# Altium schematic sheet 04-SX1257.SchDoc(04-SX1257)
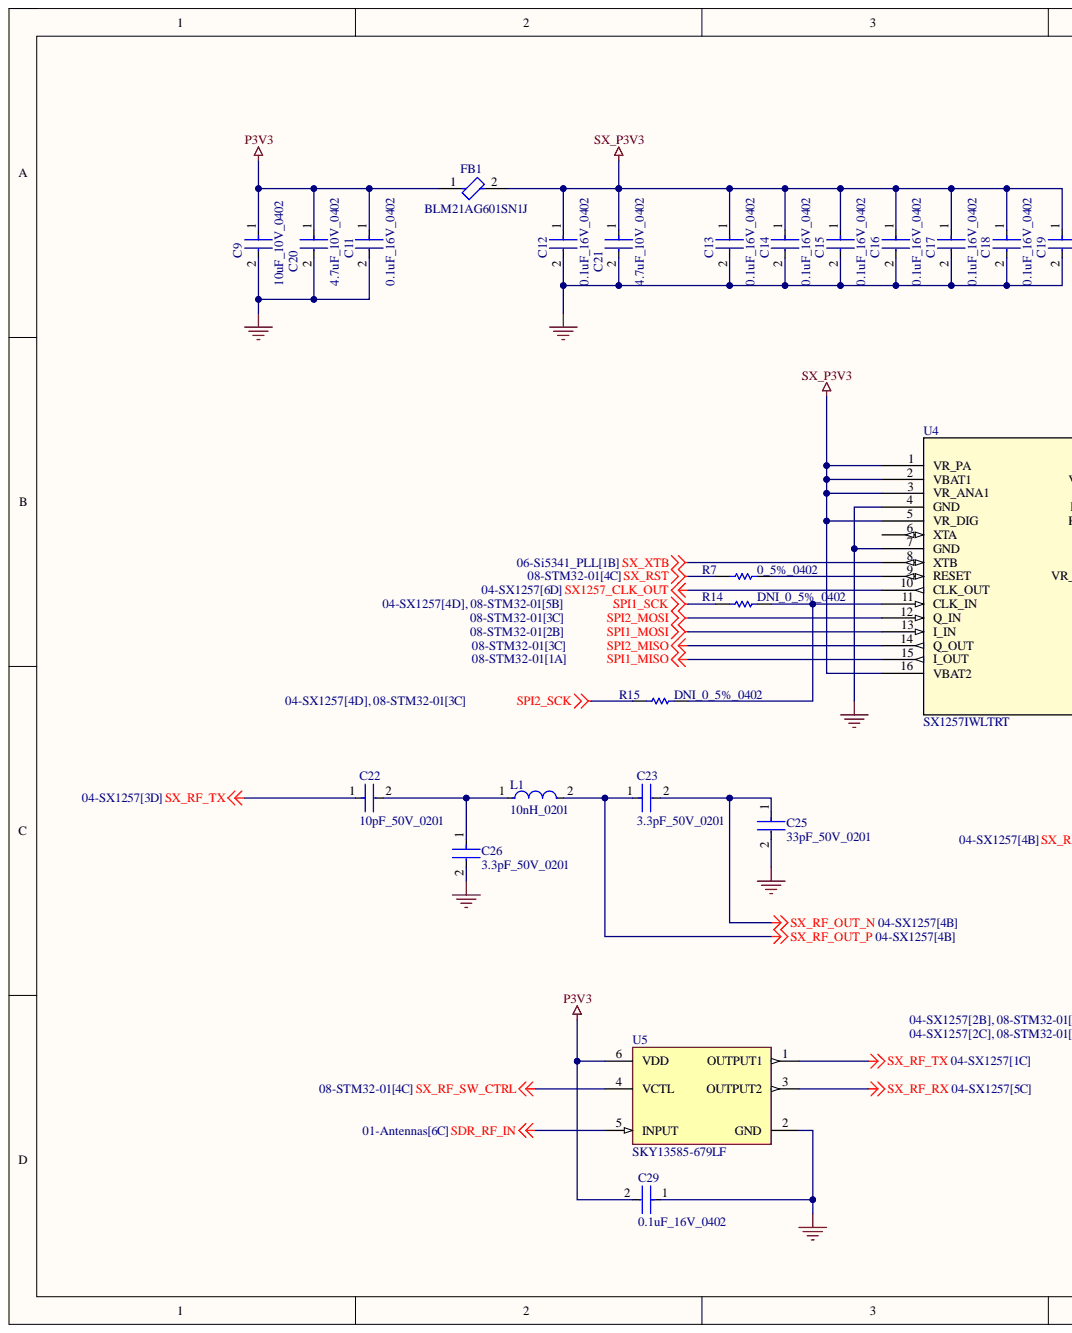
[diagram p0: 04-SX1257.SchDoc, left]
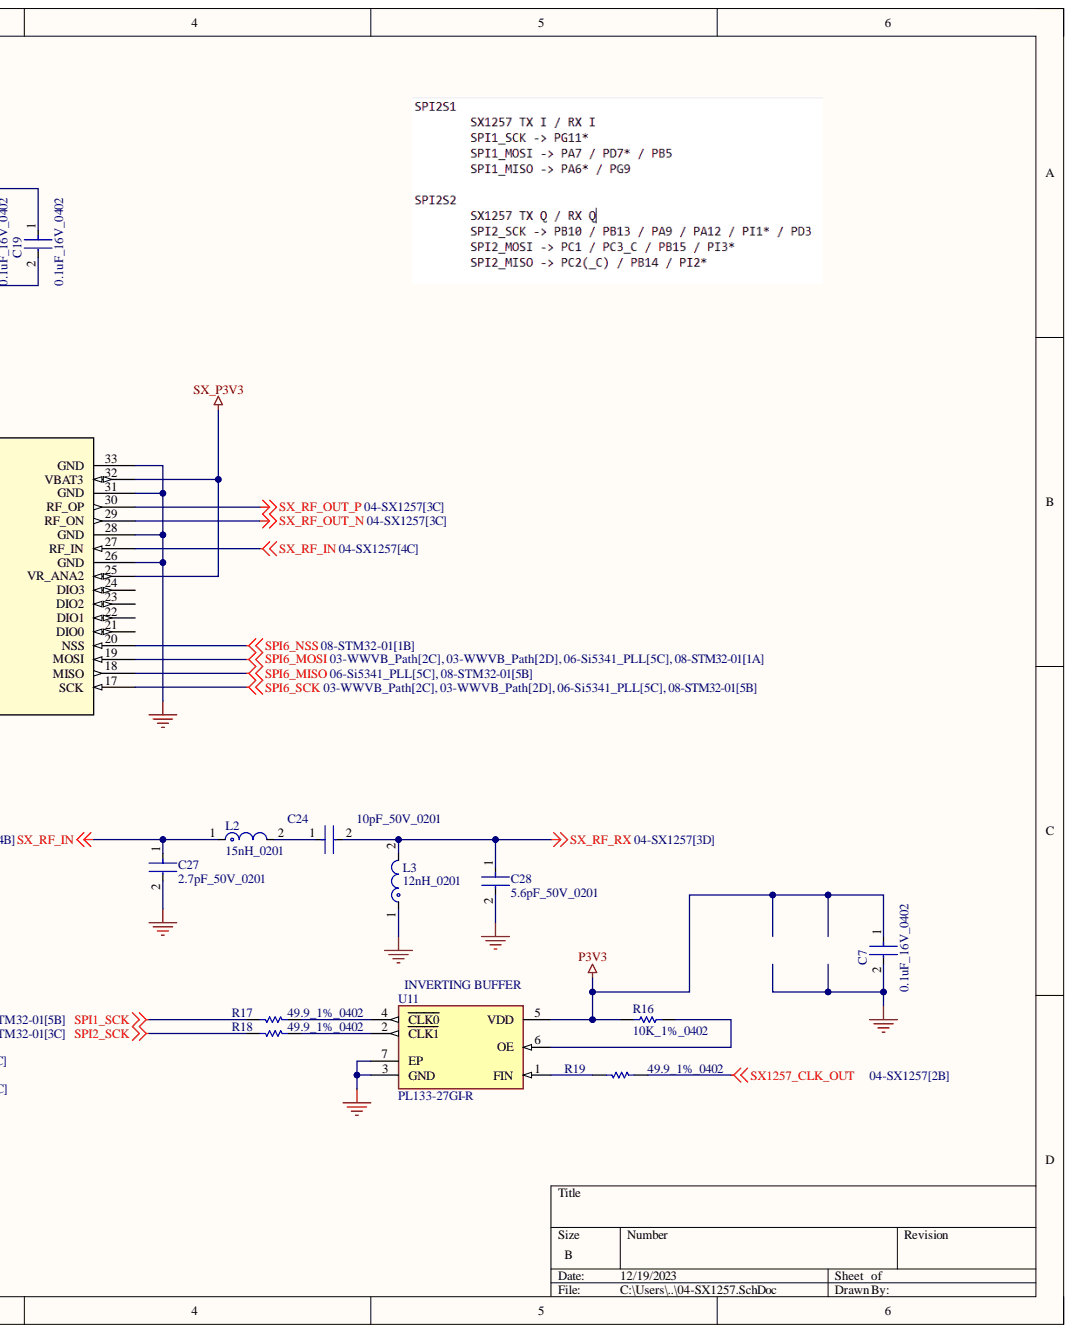
[diagram p1: 04-SX1257.SchDoc, right]

## Parts
C7  0.1uF_16V_0402  [FP-C0402-BB-MFG]
C9  10uF_10V_0402  [FP-CL05A106MP5NUNC-MFG]
C11  0.1uF_16V_0402  [FP-C0402-BB-MFG]
C12  0.1uF_16V_0402  [FP-C0402-BB-MFG]
C13  0.1uF_16V_0402  [FP-C0402-BB-MFG]
C14  0.1uF_16V_0402  [FP-C0402-BB-MFG]
C15  0.1uF_16V_0402  [FP-C0402-BB-MFG]
C16  0.1uF_16V_0402  [FP-C0402-BB-MFG]
C17  0.1uF_16V_0402  [FP-C0402-BB-MFG]
C18  0.1uF_16V_0402  [FP-C0402-BB-MFG]
C19  0.1uF_16V_0402  [FP-C0402-BB-MFG]
C20  4.7uF_10V_0402  [FP-C1005-050-0_15-MFG]
C21  4.7uF_10V_0402  [FP-C1005-050-0_15-MFG]
C22  10pF_50V_0201  [FP-CGA1A-0_1-0_03-MFG]
C23  3.3pF_50V_0201  [FP-GRM033-0_03-IPC_C]
C24  10pF_50V_0201  [FP-CGA1A-0_1-0_03-MFG]
C25  33pF_50V_0201  [FP-CGA1A-0_1-0_03-MFG]
C26  3.3pF_50V_0201  [FP-GRM033-0_03-IPC_C]
C27  2.7pF_50V_0201  [FP-GRM033-0_03-IPC_C]
C28  5.6pF_50V_0201  [FP-GRM033-0_03-MFG]
C29  0.1uF_16V_0402  [FP-C0402-BB-MFG]
FB1  BLM21AG601SN1J  [FP-BLM21-0_2-t0_85-e0_5-IPC_A]
L1  10nH_0201  [FP-LQP03HQ_02-MFG]
L2  15nH_0201  [FP-LQP03TG_02-IPC_C]
L3  12nH_0201  [FP-LQP03TG_02-MFG]
R7  0_5%_0402  [RESC1005X40X25NL05T10]
R14  DNI_0_5%_0402  [RESC1005X40X25NL05T10]  (no BOM)
R15  DNI_0_5%_0402  [RESC1005X40X25NL05T10]  (no BOM)
R16  10K_1%_0402  [RESC1005X40X25NL10T10]
R17  49.9_1%_0402  [RESC1005X40X25NL10T10]
R18  49.9_1%_0402  [RESC1005X40X25NL10T10]
R19  49.9_1%_0402  [RESC1005X40X25NL10T10]
U4  SX1257IWLTRT  [MLPQW-32_SEM]
U5  SKY13585-679LF  [FP-SKY13585-679LF-MFG]
U11  PL133-27GI-R  [FP-C04-1245-MFG]

## Nets
GND: C7.2 C9.2 C11.2 C12.2 C13.2 C14.2 C15.2 C16.2 C17.2 C18.2 C19.2 C20.2 C21.2 C25.2 C26.2 C27.2 C28.2 C29.1 L3.1 U4.4(GND) U4.7(GND) U4.26(GND) U4.28(GND) U4.31(GND) U4.33(GND) U5.2(GND) U11.3(GND) U11.7(EP)
P3V3: C7.1 C9.1 C11.1 C20.1 C29.2 FB1.1 R16.2 U5.6(VDD) U11.5(VDD)
SDR_RF_IN: U5.5(INPUT)
SPI1_MISO: U4.15(I_OUT)
SPI1_MOSI: U4.13(I_IN)
SPI1_SCK: R14.1 R17.1
SPI2_MISO: U4.14(Q_OUT)
SPI2_MOSI: U4.12(Q_IN)
SPI2_SCK: R15.1 R18.1
SPI6_MISO: U4.18(MISO)
SPI6_MOSI: U4.19(MOSI)
SPI6_NSS: U4.20(NSS)
SPI6_SCK: U4.17(SCK)
SX1257_CLK_OUT: R19.2 U4.10(CLK_OUT)
SX_P3V3: C12.1 C13.1 C14.1 C15.1 C16.1 C17.1 C18.1 C19.1 C21.1 FB1.2 U4.1(VR_PA) U4.2(VBAT1) U4.3(VR_ANA1) U4.5(VR_DIG) U4.16(VBAT2) U4.25(VR_ANA2) U4.32(VBAT3)
SX_RF_IN: C27.1 L2.1 U4.27(RF_IN)
SX_RF_OUT_N: C23.2 C25.1 U4.29(RF_ON)
SX_RF_OUT_P: C23.1 L1.2 U4.30(RF_OP)
SX_RF_RX: C24.2 C28.1 L3.2 U5.3(OUTPUT2)
SX_RF_SW_CTRL: U5.4(VCTL)
SX_RF_TX: C22.1 U5.1(OUTPUT1)
SX_RST: R7.1
SX_XTB: U4.8(XTB)
NetC22_2: C22.2 C26.1 L1.1
NetC24_1: C24.1 L2.2
NetR7_2: R7.2 U4.9(RESET)
NetR14_2: R14.2 R15.2 U4.11(CLK_IN)
NetR16_1: R16.1 U11.6(OE)
NetR17_2: R17.2 U11.4(CLK0)
NetR18_2: R18.2 U11.2(CLK1)
NetR19_1: R19.1 U11.1(FIN)

Not connected: U4 6(XTA) 21(DIO0) 22(DIO1) 23(DIO2) 24(DIO3)

## Library data of the parts
C7 C11-C19 C29 = CMP-1034-02068-3: CAP CER 0.1UF 16V 5% X7R 0402; Manufacturer: Kemet; Manufacturer Part Number: C0402C104J4RACTU; Capacitance: 0.10 μF; Material: Ceramic; Temperature Coefficient: 15%; Tolerance: 0.05; Value: 0.10 μF; Automotive: No; Category: Cap; Component Kind: Standard; ComponentLink2Description: Datasheet; ComponentLink2URL: https://content.kemet.com/datasheets/KEM_C1002_X7R_SMD.pdf; Device Class L1: Passive Components; Device Class L2: Capacitors; Device Class L3: Ceramic Capacitors; Digikey Description: CAP CER 0.1UF 16V 5% X7R 0402; Height: 0.55mm; Ipc Land Pattern Name: CAPC10050X50; Lead Free: Yes; Library Name: Altium Content Vault; Mouser Description: CAP CER 0.1UF 16V X7R 0402; Octopart: https://octopart.com/c0402c104j4ractu-kemet-12573893; Package: C0402-BB; RoHS: TRUE; Temperature: -55°C to +125°C; Temperature Characteristic: X7R; Temperature Range High: +125°C; Temperature Range Low: -55°C; Voltage Rating: 16 V
C9 = CMP-13271-000002-1: CAP CER 10UF 10V X5R 0402; Manufacturer: Samsung; Manufacturer Part Number: CL05A106MP5NUNC; Material: Ceramic; Temperature Coefficient: 15%; Tolerance: 20%; Automotive: No; Capacitance: 10uF; Category: Cap; Component Kind: Standard; ComponentLink2Description: Datasheet; ComponentLink2URL: http://www.samsungsem.com/kr/support/product-search/mlcc/__icsFiles/afieldfile/2019/05/10/19-CL05A106MP5NUNC.pdf; Device Class L1: Passive Components; Device Class L2: Capacitors; Device Class L3: Ceramic Capacitors; Digikey Description: CAP CER 10UF 10V X5R 0402; Height: 0.7mm; Ipc Land Pattern Name: CAPC10050X50; Lead Free: Yes; Library Name: Altium Content Vault; Octopart: https://octopart.com/cl05a106mp5nunc-samsung-22457395; Package: CL05A106MP5NUNC; RoHS: TRUE; Temperature: -55°C to +85°C; Temperature Characteristic: X5R; Temperature Range High: +85°C; Temperature Range Low: -55°C; Value: 10uF; Voltage Rating: 10V
C20 C21 = CMP-2000-05997-2: CAP CER 4.7UF 10V X5R 0402; Dielectric Material: Ceramic; Tolerance: 10%; Capacitance: 4.7uF; Case Code (Imperial): 0402; Case Code (Metric): 1005; Case/Package: 0402; Component Kind: Standard; Dielectric: X5R; Lead Free: Lead Free; Length: 0.039inch; Library Name: Altium Content Vault; Max Operating Temperature: 85°C; Min Operating Temperature: -55°C; Mount: Surface Mount; Package Quantity: 10000; Packaging: Cut Tape; REACH SVHC: No SVHC; RoHS Compliant: Yes; Series: C; Thickness: 0.022inch; Voltage Rating: 10V; Voltage Rating (DC): 10V; Width: 0.02inch
C22 C24 = CMP-08246-000166-1: Cap Ceramic 10pF 50V C0G 0.5pF Pad SMD 0201 125°C Automotive T/R; Capacitance: 10pF; Case Code (Imperial): 0201; Case Code (Metric): 0603; Case/Package: 0201; Component Kind: Standard; Composition: Ceramic; Depth: 0.3mm; Dielectric: C0G; Height: 0.3mm; Length: 0.6mm; Library Name: Altium Content Vault; Max Operating Temperature: 125°C; Min Operating Temperature: -55°C; Mount: Surface Mount; Package Quantity: 1; Packaging: Tape and Reel; Ratings: AEC-Q200; Termination: SMD/SMT; Thickness: 0.013inch; Voltage Rating: 50V; Voltage Rating (DC): 50V; Weight: 6E-06oz; Width: 0.012inch
C23 C26 = CMP-2010-01117-2: Chip Multilayer Ceramic Capacitors for General Purpose, 0201, 3.3pF, C0G, 30ppm/°C, 0.25pF, 50V; Capacitance: 3.3pF; Case Code (Imperial): 0201; Case Code (Metric): 0603; Case/Package: 0201; Component Kind: Standard; Dielectric: C0G; Height: 0.3mm; Length: 0.024inch; Library Name: Altium Content Vault; Max Operating Temperature: 125°C; Min Operating Temperature: -55°C; Mount: Surface Mount; Package Quantity: 50000; Packaging: Tape and Reel; Termination: SMD/SMT; Thickness: 0.013inch; Voltage Rating: 50V; Voltage Rating (DC): 50V; Width: 0.012inch
C25 = CMP-08246-002436-1: Cap Ceramic 33pF 50V C0G 5% Pad SMD 0201 125°C Automotive T/R; Capacitance: 33pF; Case Code (Imperial): 0201; Case Code (Metric): 0603; Case/Package: 0201; Component Kind: Standard; Composition: Ceramic; Depth: 0.3mm; Dielectric: C0G; Height: 0.3mm; Length: 0.6mm; Library Name: Altium Content Vault; Max Operating Temperature: 125°C; Min Operating Temperature: -55°C; Mount: Surface Mount; Package Quantity: 1; Packaging: Tape and Reel; Ratings: AEC-Q200; Termination: SMD/SMT; Thickness: 0.013inch; Tolerance: 5%; Voltage Rating: 50V; Voltage Rating (DC): 50V; Weight: 6E-06oz; Width: 0.012inch
C27 = CMP-2010-01540-2: Chip Multilayer Ceramic Capacitors for General Purpose, 0201, 2.7pF, C0G, 30ppm/°C, 0.25pF, 50V; Capacitance: 2.7pF; Case Code (Imperial): 0201; Case Code (Metric): 0603; Case/Package: 0201; Component Kind: Standard; Dielectric: C0G; Height: 0.3mm; Lead Free: Lead Free; Length: 0.024inch; Library Name: Altium Content Vault; Max Operating Temperature: 125°C; Min Operating Temperature: -55°C; Mount: Surface Mount; Package Quantity: 15000; Packaging: Tape and Reel; Termination: SMD/SMT; Thickness: 0.013inch; Voltage Rating: 50V; Voltage Rating (DC): 50V; Width: 0.012inch
C28 = CMP-2010-01150-2: Chip Multilayer Ceramic Capacitors for General Purpose, 0201, 5.6pF, C0G, 30ppm/°C, 0.1pF, 50V; Capacitance: 5.6pF; Case Code (Imperial): 0201; Case Code (Metric): 0603; Case/Package: 0201; Component Kind: Standard; Depth: 0.3mm; Dielectric: C0G; Height: 0.3mm; Length: 0.6mm; Library Name: Altium Content Vault; Max Operating Temperature: 125°C; Min Operating Temperature: -55°C; Mount: Surface Mount; Package Quantity: 15000; Packaging: Tape and Reel; Termination: SMD/SMT; Thickness: 0.013inch; Voltage Rating: 50V; Voltage Rating (DC): 50V; Width: 0.012inch
FB1 = CMP-0686-00643-3: Chip Ferrite Bead, 0805, 600Ω @ 100MHz, 0.2Ω, 25%, 700mA; Current Rating: 600mA; DC Current: 200mA; DC Resistance (DCR): 210mR; Impedance: 600R; Number of Circuits: 1; Case Code (Imperial): 0805; Case Code (Metric): 2012; Case/Package: 0805; Component Kind: Standard; Core Material: Ferrite; Depth: 0.85mm; Height: 1.25mm; Length: 2.2mm; Library Name: Altium Content Vault; Max Current Rating: 200mA; Max Operating Temperature: 125°C; Min Operating Temperature: -55°C; Number of Pins: 2; Packaging: Tape and Reel; Radiation Hardening: No; RoHS Compliant: Yes; Termination: Flat; Test Frequency: 100MHz; Tolerance: 25%
L1 = CMP-06042-008104-1: Film type RF Inductor 10nH ±3% 300mA 0.4Ω 0201 (0603); Core Material: Non-Magnetic; DC Resistance (DCR): 400mR; Self Resonant Frequency: 4.5GHz; Shielding: Unshielded; Test Frequency: 500MHz; Case Code (Imperial): 0201; Case Code (Metric): 0603; Case/Package: 0201; Component Kind: Standard; Current Rating: 300mA; Inductance: 10nH; Library Name: Altium Content Vault; Max Operating Temperature: 125°C; Min Operating Temperature: -55°C; Mount: Surface Mount; Packaging: Tape and Reel; Resistor Type: Thin Film; Tolerance: 3%
L2 = CMP-06042-000019-2: Film type RF Inductor 15nH ±5% 250mA 0.7Ω 0201 (0603); Core Material: Non-Magnetic; DC Resistance (DCR): 700mR; Q Factor: 12; Self Resonant Frequency: 2.6GHz; Shielding: Unshielded; Test Frequency: 500MHz; Case Code (Imperial): 0201; Case Code (Metric): 0603; Case/Package: 0201; Component Kind: Standard; Current Rating: 250mA; DC Current: 250mA; Depth: 0.33mm; Height: 0.3mm; Height - Seated (Max): 0.013inch; Inductance: 15nH; Lead Free: Lead Free; Length: 0.024inch; Library Name: Altium Content Vault; Max DC Current: 250mA; Max Operating Temperature: 125°C; Military Standard: Not; Min Operating Temperature: -55°C; Mount: Surface Mount; Package Quantity: 15000; Packaging: Tape and Reel; Resistor Type: Thin Film; Termination: SMD/SMT; Tolerance: 5%; Width: 0.3mm
L3 = CMP-06042-011957-1: Film type RF Inductor 12nH ±5% 250mA 0.7Ω 0201 (0603); Core Material: Non-Magnetic; DC Resistance (DCR): 700mR; Q Factor: 12; Self Resonant Frequency: 2.9GHz; Shielding: Unshielded; Test Frequency: 500MHz; Case Code (Imperial): 0201; Case Code (Metric): 0603; Case/Package: 0201; Component Kind: Standard; Current Rating: 250mA; DC Current: 250mA; Depth: 0.33mm; Height: 0.3mm; Height - Seated (Max): 0.013inch; Inductance: 12nH; Lead Free: Lead Free; Length: 0.024inch; Library Name: Altium Content Vault; Max DC Current: 250mA; Max Operating Temperature: 125°C; Military Standard: Not; Min Operating Temperature: -55°C; Mount: Surface Mount; Package Quantity: 15000; Packaging: Tape and Reel; Radiation Hardening: No; Resistor Type: Thin Film; Termination: SMD/SMT; Tolerance: 5%; Width: 0.012inch
R7 R14 R15 = CMP-2002-06457-1: Manufacturer: Panasonic; Part Number: ERJ-U020R00X; Library Name: Altium Content Vault
  R7: Component Kind: Standard
R16 = CMP-2002-04694-1: Manufacturer: Bourns; Part Number: CR0402-FX-1002GLF; Component Kind: Standard; Library Name: Altium Content Vault
R17-R19 = CMP-2002-04448-1: Manufacturer: KOA Speer; Part Number: RK73H1ETTP49R9F; Component Kind: Standard; Library Name: Altium Content Vault
U4 = SX1257IWLTRT: No Description Available; Mfr_Name: Semtech; Component Kind: Standard; Library Name: SX1257IWLTRT.SchLib; Manufacturer_Part_Number: SX1257IWLTRT; RefDes: RefDes; Type: DEV; Copyright: Copyright (C) 2023 Ultra Librarian. All rights reserved.
U5 = CMP-85923-000009-1: IC RF SWITCH SPDT 6GHZ 6MLPD; IIP3: 56dBm; Impedance: 50R; Insertion Loss: 0.5dB; Test Frequency: 2.5GHz; Component Kind: Standard; Library Name: Altium Content Vault; Max Operating Temperature: 90°C; Min Operating Temperature: -40°C; Packaging: Cut Tape; RoHS Compliant: Yes; Series: -; Throw Configuration: SPDT
U11 = CMP-06262-000005-1: IC CLK BUFFER 1:2 150MHZ 6DFN; Input: LVCMOS; Number of Circuits: 1; Case/Package: DFN; Component Kind: Standard; Library Name: Altium Content Vault; Max Frequency: 150MHz; Max Operating Temperature: 85°C; Min Operating Temperature: -40°C; Mount: Surface Mount; Package Quantity: 3000; Packaging: Tape and Reel; RoHS Compliant: Yes
